# Altium schematic sheet POWER.SchDoc
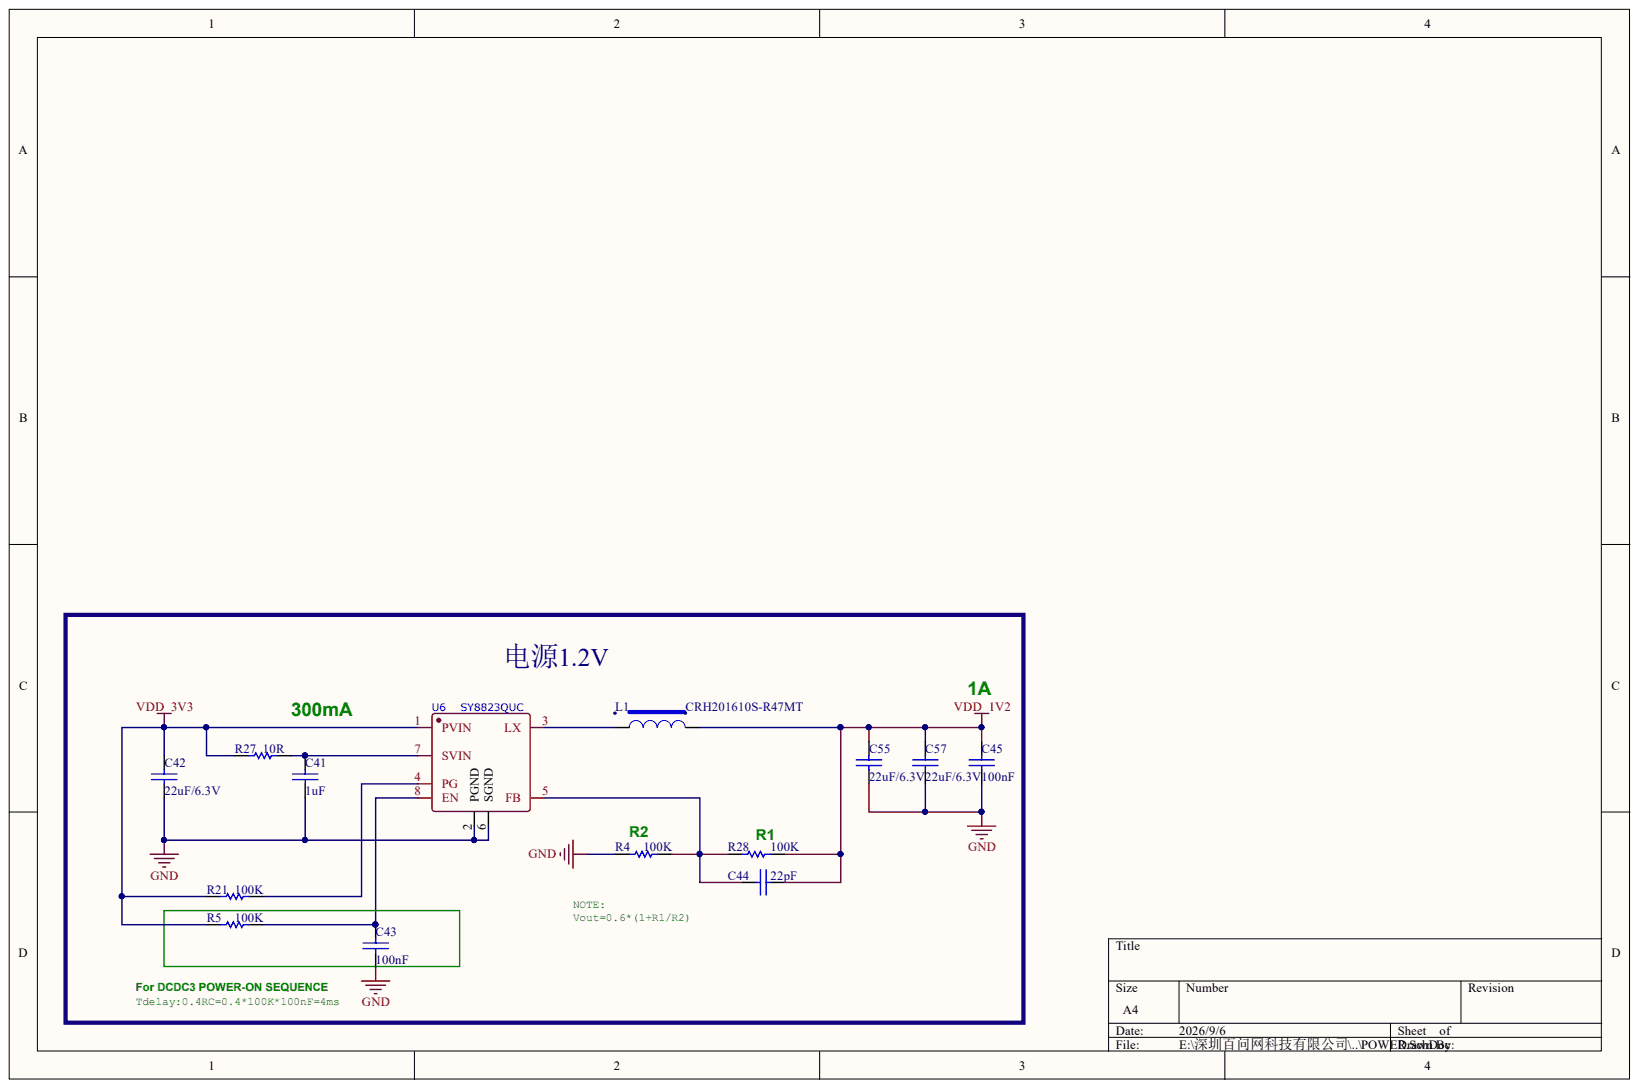
[diagram p0: POWER.SchDoc]

## Parts
C41  1uF  [0201_CAP_SMT]
C42  22uF/6.3V  [0402]
C43  100nF  [0201_CAP_SMT]
C44  22pF  [0201_CAP_SMT]
C45  100nF  [0201_CAP_SMT]
C55  22uF/6.3V  [0402]
C57  22uF/6.3V  [0402]
L1  CRH201610S-R47MT  [IND-SMD_L2.0-W1.6-DFP201610TF]
R4  100K  [0201_RES_SMT]
R5  100K  [0201_RES_SMT]
R21  100K  [0201_RES_SMT]
R27  10R  [0201_RES_SMT]
R28  100K  [0201_RES_SMT]
U6  SY8823QUC  [QFN-8_L2.0-W1.5-P0.45-BL_SILERGY_SY8823QUC]

## Nets
GND: C41.2 C42.2 C43.2 C45.2 C55.2 C57.2 R4.1 U6.2(PGND) U6.6(SGND)
VDD_1V2: C44.2 C45.1 C55.1 C57.1 L1.2 R28.2
VDD_3V3: C42.1 R5.1 R21.1 R27.1 U6.1(PVIN)
NetC41_1: C41.1 R27.2 U6.7(SVIN)
NetC43_1: C43.1 R5.2 U6.8(EN)
NetC44_1: C44.1 R4.2 R28.1 U6.5(FB)
NetL1_1: L1.1 U6.3(LX)
NetR21_2: R21.2 U6.4(PG)

## Library data of the parts
C41-C45 C55 C57 = CAP: 陶瓷电容; Part Number: A0406400002; Dielectric: X5R; LC_CODE: C1547; Tolerance: 10%; Voltage: 50V; Component Kind: Standard; Library Name: ZX_MyLib.SchLib; SIZE: 0201
L1 = L-L2520_Dup1: Parameter: L2520; PCB Footprint: LP200_250H100; Component Kind: Standard; JLC NO: C520196
R4 R5 R21 R27 R28 = Res1: Resistor; PartNumber: RES2.2K; Component Kind: Standard; LatestRevisionDate: 17-Jul-2002; LatestRevisionNote: Re-released for DXP Platform.; Library Name: Miscellaneous Devices.IntLib; PackageReference: 0402; Published: 8-Jun-2000; Signal Integrity: Res; Simulation: RESISTOR; Publisher: Altium Limited
  R4 R5 R21 R28: Value: 100K
  R27: Value: 10R
U6 = SY8823QUC: Component Kind: Standard; JLC NO: C2844075; Library Name: HM2.SchLib
